# Altium schematic sheet Sensor_Box_Ethernet.SchDoc
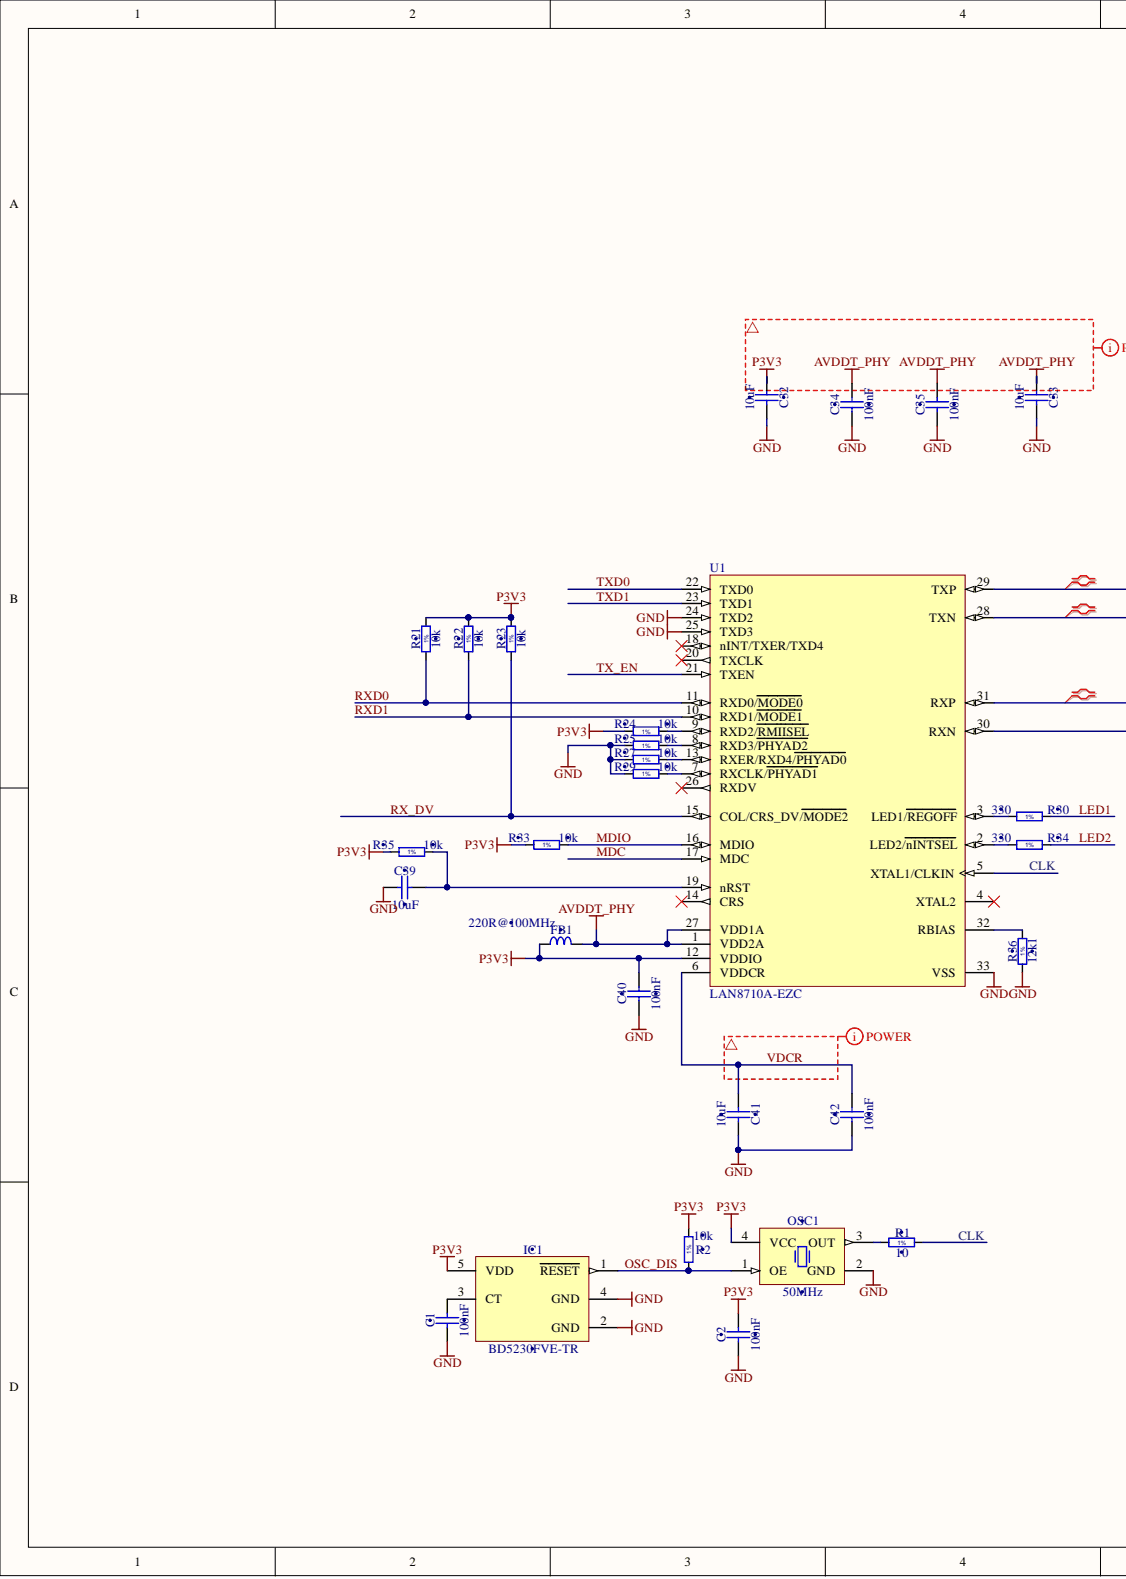
[diagram p0: Sensor_Box_Ethernet.SchDoc, left]
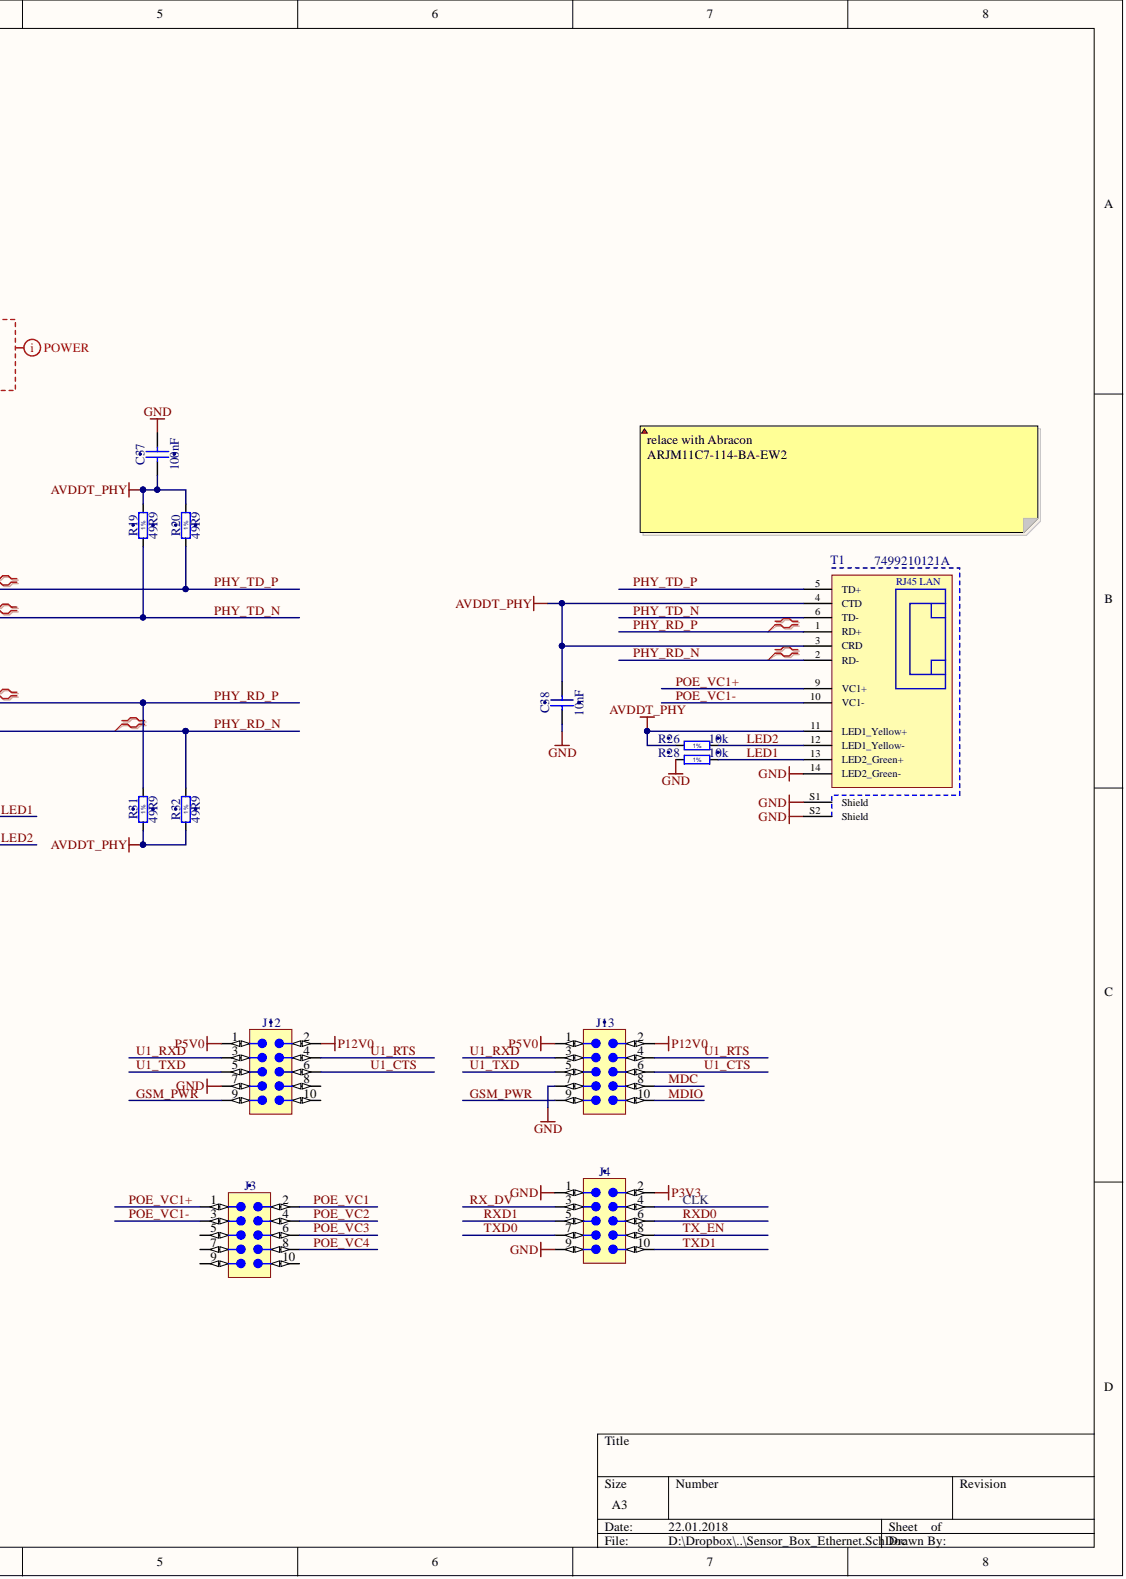
[diagram p1: Sensor_Box_Ethernet.SchDoc, right]

## Parts
C1  100nF  [CAPC1005X55N]
C2  100nF  [CAPC1005X55N]
C32  10uF  [CAPC2012X135N]
C33  10uF  [CAPC2012X135N]
C34  100nF  [CAPC1005X55N]
C35  100nF  [CAPC1005X55N]
C37  100nF  [CAPC1005X55N]
C38  10nF  [CAPC1608X87N]
C39  10uF  [CAPC2012X135N]
C40  100nF  [CAPC1005X55N]
C41  10uF  [CAPC2012X135N]
C42  100nF  [CAPC1005X55N]
FB1  220R@100MHz  [INDC2012X105N]
IC1  BD5230FVE-TR  [SOT95P280X145-5N]
J3  edge  [AVX_00-9159-010-061-916]  (value not drawn)
J4  edge  [AVX_00-9159-010-061-916]  (value not drawn)
J12  edge  [AVX_00-9159-010-061-916]  (value not drawn)
J13  edge  [AVX_00-9159-010-061-916]  (value not drawn)
OSC1  50MHz  [OSCSC254P320X500X130-4N]
R1  10  [RESC1005X40N]
R2  10k  [RESC1005X40N]
R19  49R9  [RESC1005X40N]
R20  49R9  [RESC1005X40N]
R21  10k  [RESC1005X40N]
R22  10k  [RESC1005X40N]
R23  10k  [RESC1005X40N]
R24  10k  [RESC1005X40N]
R25  10k  [RESC1005X40N]
R26  10k  [RESC1005X40N]
R27  10k  [RESC1005X40N]
R28  10k  [RESC1005X40N]
R29  10k  [RESC1005X40N]
R30  330  [RESC1005X40N]
R31  49R9  [RESC1005X40N]
R32  49R9  [RESC1005X40N]
R33  10k  [RESC1005X40N]
R34  330  [RESC1005X40N]
R35  10k  [RESC1005X40N]
R36  12k1  [RESC1005X40N]
T1  7499210121A  [7499210121A]
U1  LAN8710A-EZC  [MCHP-QFN-32-5x5_V1]

## Nets
AVDDT_PHY: C33.1 C34.2 C35.2 C37.1 C38.2 FB1.2 R19.2 R20.2 R26.2 R31.1 R32.1 T1.3(CRD) T1.4(CTD) T1.11(LED1_Yellow+) U1.1(VDD2A) U1.27(VDD1A)
GND: C1.1 C2.1 C32.2 C33.2 C34.1 C35.1 C37.2 C38.1 C39.1 C40.1 C41.2 C42.1 IC1.2(GND) IC1.4(GND) J4.1 J4.9 J12.7 J13.7 OSC1.2(GND) R25.2 R27.2 R28.2 R29.2 R36.1 T1.14(LED2_Green-) T1.S1(Shield) T1.S2(Shield) U1.24(TXD2) U1.25(TXD3) U1.33(VSS)
P3V3: C2.2 C32.1 C40.2 FB1.1 IC1.5(VDD) J4.2 OSC1.4(VCC) R2.1 R21.2 R22.2 R23.2 R24.2 R33.2 R35.2 U1.12(VDDIO)
P5V0: J12.1 J13.1
P12V0: J12.2 J13.2
CLK: J4.4 R1.2 U1.5(XTAL1/CLKIN)
GSM_PWR: J12.9 J13.9
LED1: R28.1 R30.2 T1.13(LED2_Green+)
LED2: R26.1 R34.2 T1.12(LED1_Yellow-)
MDC: J13.8 U1.17(MDC)
MDIO: J13.10 R33.1 U1.16(MDIO)
OSC_DIS: IC1.1(RESET) OSC1.1(OE) R2.2
PHY_RD_N: R32.2 T1.2(RD-) U1.30(RXN)
PHY_RD_P: R31.2 T1.1(RD+) U1.31(RXP)
PHY_TD_N: R19.1 T1.6(TD-) U1.28(TXN)
PHY_TD_P: R20.1 T1.5(TD+) U1.29(TXP)
POE_VC1: J3.2
POE_VC1+: J3.1 T1.9(VC1+)
POE_VC1-: J3.3 T1.10(VC1-)
POE_VC2: J3.4
POE_VC3: J3.6
POE_VC4: J3.8
RX_DV: J4.3 R23.1 U1.15(COL/CRS_DV/MODE2)
RXD0: J4.6 R21.1 U1.11(RXD0/MODE0)
RXD1: J4.5 R22.1 U1.10(RXD1/MODE1)
TX_EN: J4.8 U1.21(TXEN)
TXD0: J4.7 U1.22(TXD0)
TXD1: J4.10 U1.23(TXD1)
U1_CTS: J12.6 J13.6
U1_RTS: J12.4 J13.4
U1_RXD: J12.3 J13.3
U1_TXD: J12.5 J13.5
VDCR: C41.1 C42.2 U1.6(VDDCR)
NetC1_2: C1.2 IC1.3(CT)
NetC39_2: C39.2 R35.1 U1.19(nRST)
NetOSC1_3: OSC1.3(OUT) R1.1
NetR24_1: R24.1 U1.9(RXD2/RMIISEL)
NetR25_1: R25.1 U1.8(RXD3/PHYAD2)
NetR27_1: R27.1 U1.13(RXER/RXD4/PHYAD0)
NetR29_1: R29.1 U1.7(RXCLK/PHYAD1)
NetR30_1: R30.1 U1.3(LED1/REGOFF)
NetR34_1: R34.1 U1.2(LED2/nINTSEL)
NetR36_2: R36.2 U1.32(RBIAS)
N00009:
N00010:

Not connected: J3 5 7 9 10; J12 8 10; U1 4(XTAL2) 14(CRS) 18(nINT/TXER/TXD4) 20(TXCLK) 26(RXDV)

## Library data of the parts
C1 C2 C34 C35 C37 C40 C42 = CC0402_100NF_16V_10%_X5R: Non-polarised capacitor; Manufacturer: GENERIC; Manufacturer1 Part Number: GRM155R61C104KA88D; Part Description: SMD Multilayer Chip Ceramic Capacitor; Database Table Name: Capacitors SMD; TC: X5R; Tolerance: ±10%; Voltage: 16V; Case: 0402; Component Kind: Standard; Component Type: Standard; CreateDate: 10.12.2013; HelpURL: \\cern.ch\dfs\Applications\Altium\Datasheets\CC0402_X5R_MURATA_GRM.pdf; LatestRevisionDate: 10.12.2013; Library Name: Capacitors.DbLib; Manufacturer1 ComponentHeight: 0.55mm; Manufacturer1 Example: MURATA; Manufacturer Part Number: CC0402_100NF_16V_10%_X5R; Mounted: Yes; Part Number: CC0402_100NF_16V_10%_X5R; Pin Count: 2; Sense: No; SMD: Yes; Socket: No; Status: None; Value: 100nF; Author: CERN DEM JLC
C32 C33 C39 C41 = CC0805_10UF_16V_10%_X5R: Non-polarised capacitor; Manufacturer: GENERIC; Manufacturer1 Part Number: C0805C106K4PAC; Part Description: SMD Multilayer Chip Ceramic Capacitor; Database Table Name: Capacitors SMD; TC: X5R; Tolerance: ±10%; Voltage: 16V; Case: 0805; Component Kind: Standard; Component Type: Standard; CreateDate: 03.12.2007; HelpURL: \\cern.ch\dfs\Applications\Altium\Datasheets\CC1206_X5R_KEMET.pdf; LatestRevisionDate: 03.12.2007; Library Name: Capacitors.DbLib; Manufacturer1 ComponentHeight: 1.4mm; Manufacturer1 Example: KEMET; Manufacturer Part Number: CC0805_10UF_16V_10%_X5R; Mounted: Yes; Part Number: CC0805_10UF_16V_10%_X5R; Pin Count: 2; Sense: No; SMD: Yes; Socket: No; Status: Preferred; Value: 10uF; Author: CERN DEM JLC
C38 = CC0603_10NF_50V_5%_C0G: Non-polarised capacitor; Manufacturer: GENERIC; Manufacturer1 Part Number: C1608C0G1H103J080AA; Part Description: SMD Multilayer Chip Ceramic Capacitor; Database Table Name: Capacitors SMD; TC: C0G/NP0; Tolerance: ±5%; Voltage: 50V; Case: 0603; Component Kind: Standard; Component Type: Standard; CreateDate: 10.12.2014; HelpURL: \\cern.ch\dfs\Applications\Altium\Datasheets\CC0603_C0G_TDK_C.pdf; LatestRevisionDate: 10.12.2014; Library Name: Capacitors.DbLib; Manufacturer1 ComponentHeight: 0.9mm; Manufacturer1 Example: TDK; Manufacturer Part Number: CC0603_10NF_50V_5%_C0G; Mounted: Yes; Part Number: CC0603_10NF_50V_5%_C0G; Pin Count: 2; Sense: No; SMD: Yes; Socket: No; Status: Preferred; Value: 10nF; Author: CERN DEM JLC
FB1 = IND0805_MURATA_BLM21PG221SN1D: Inductor; Manufacturer: MURATA; Part Description: SMD EMIFIL Suppression Chip Ferrite Bead; Database Table Name: Inductors SMD; Power: 2A; Resistance: 0.05R; Tolerance: ±25%; Case: 0805; ComponentHeight: 1.05mm; Component Kind: Standard; Component Type: Standard; CreateDate: 2010-01-25; HelpURL: \\cern.ch\dfs\Applications\Altium\Datasheets\IND0402_MURATA_BLM21P.pdf; LatestRevisionDate: 2010-01-25; Library Name: Inductors & Transformers.DbLib; Manufacturer Part Number: BLM21PG221SN1D; Mounted: Yes; Part Number: IND0805_MURATA_BLM21PG221SN1D; Pin Count: 2; SMD: Yes; Socket: No; Status: None; Table: Inductors SMD; Value: 220R@100MHz; Author: CERN DEM JLC
IC1 = (no symbol name): Free Delay Time Setting  CMOS Voltage Detector; Manufacturer: Rohm; Part Description: 5V, Low Quiescent Current, Programmable-Delay Supervisory Circuit; Bonding: No; PressFit: No; Case: SOT23-5; ComponentHeight: 1.45mm; Component Kind: Standard; Component Type: Standard; CreateDate: 02.03.2015; Device: BD5230FVE-TR; HelpURL: \\cern.ch\dfs\Applications\Altium\Datasheets\TPS3808G50DBVT.pdf; LatestRevisionDate: 02.03.2015; Library Name: LIBRARY_SCH.SCHLIB; Manufacturer Part Number: BD5230FVE-TR; Mounted: Yes; PackageDescription: SOT23, 5-Leads, Body 1.6x3.0mm, Pitch 0.95mm, IPC Medium Density; Part Number: BD5230FVE-TR; Pin Count: 5; Sense: No; SMD: Yes; Socket: No; Author: GK
J3 J4 J12 J13 = (no symbol name): edge inline connector; Manufacturer: AVX; Part Description: 2.00mm (.079") Pitch Milli-Grid™ Header, Vertical, Shrouded, Center Polarization Slot, Locking Window, 14 Circuits (Customized PCB Edge assembly); PressFit: No; ComponentHeight: 3.15mm; Component Kind: Standard; Component Type: Standard; CreateDate: 13.04.2010; Family: edge; HelpURL: \\cern.ch\dfs\Applications\Altium\Datasheets\MOLEX_87831-1420.pdf; LatestRevisionDate: 13.04.2010; Library Name: LIBRARY_SCH.SCHLIB; Manufacturer Part Number: AVX_00-9159-010-061-916; Mounted: Yes; Part Number: AVX_00-9159-010-061-916; Pin Count: 14; Sense: No; SMD: No; Socket: No; Status: None; Author: GK
OSC1 = OSC_50MHZ_CTS_636L3I050M00000: Oscillator 4 pins 1=OE 2=GND 3=OUT 4=VCC; Manufacturer: CTS ELECTRONIC COMPONENTS; Part Description: 3.3V, ±50ppm, 30pF, -40..85°C, HCMOS/TTL Clock Oscillator, 636 Series; Bonding: No; Database Table Name: Crystals & Oscillators; PressFit: No; ComponentHeight: 1.3mm; Component Kind: Standard; Component Type: Standard; CreateDate: 22.09.2015; HelpURL: \\cern.ch\dfs\Applications\Altium\Datasheets\OSC_CTS_636.pdf; LatestRevisionDate: 22.09.2015; Library Name: ICs And Semiconductors.DbLib; Manufacturer Part Number: 636L3I050M00000; Mounted: Yes; PackageDescription: Oscillator, Side Concave; 2.54mm Pitch; 4 pin, 3.20mm L X 5.00mm W X 1.30mm H, IPC Medium Density; Part Number: OSC_50MHZ_CTS_636L3I050M00000; Pin Count: 4; Sense: No; SMD: Yes; Socket: No; Value: 50MHz; Author: CERN DEM JMW
R1 = R0402_10R_1%_0.0625W_200PPM: Resistor - 1%; Manufacturer: GENERIC; Manufacturer1 Part Number: 232270671009L; Part Description: General Purpose Thick Film Chip Resistor; Database Table Name: Resistors SMD; PressFit: No; TC: ±200ppm/°C; Case: 0402; Component Kind: Standard; Component Type: Standard; CreateDate: 24.06.2009; HelpURL: \\cern.ch\dfs\Applications\Altium\Datasheets\R0402_Phycomp_RC0402.pdf; LatestRevisionDate: 17.10.2012; Library Name: Resistors.DbLib; Manufacturer1 ComponentHeight: 0.4mm; Manufacturer1 Example: YAGEO PHYCOMP; Manufacturer Part Number: R0402_10R_1%_0.0625W_200PPM; Mounted: Yes; Part Number: R0402_10R_1%_0.0625W_200PPM; Pin Count: 2; Power: 0.0625W; Sense: No; SMD: Yes; Socket: No; Status: Not Recommended; Tolerance: ±1%; Value: 10; Author: CERN DEM JLC
R2 R21-R29 R33 R35 = R0402_10K_1%_0.0625W_100PPM: Resistor - 1%; Manufacturer: GENERIC; Manufacturer1 Part Number: 232270671003L; Part Description: General Purpose Thick Film Chip Resistor; Database Table Name: Resistors SMD; TC: ±100ppm/°C; Case: 0402; Component Kind: Standard; Component Type: Standard; CreateDate: 2007-12-03; HelpURL: \\cern.ch\dfs\Applications\Altium\Datasheets\R0402_Phycomp_RC0402.pdf; LatestRevisionDate: 2012-10-17; Library Name: Resistors.DbLib; Manufacturer1 ComponentHeight: 0.4mm; Manufacturer1 Example: YAGEO PHYCOMP; Manufacturer Part Number: R0402_10K_1%_0.0625W_100PPM; Mounted: Yes; Part Number: R0402_10K_1%_0.0625W_100PPM; Pin Count: 2; Power: 0.0625W; SMD: Yes; Socket: No; Status: Not recommended; Table: Resistors SMD; Tolerance: ±1%; Value: 10k; Author: CERN DEM JLC
R19 R20 R31 R32 = R0402_49R9_1%_0.0625W_100PPM: Resistor - 1%; Manufacturer: GENERIC; Manufacturer1 Part Number: 232270674999L or RC0402FR-0749R9L; Part Description: General Purpose Thick Film Chip Resistor; Database Table Name: Resistors SMD; TC: ±100ppm/°C; Case: 0402; Component Kind: Standard; Component Type: Standard; CreateDate: 2012-10-24; HelpURL: \\cern.ch\dfs\Applications\Altium\Datasheets\R0402_Phycomp_RC0402.pdf; LatestRevisionDate: 2012-10-24; Library Name: Resistors.DbLib; Manufacturer1 ComponentHeight: 0.4mm; Manufacturer1 Example: YAGEO PHYCOMP; Manufacturer Part Number: R0402_49R9_1%_0.0625W_100PPM; Mounted: Yes; Part Number: R0402_49R9_1%_0.0625W_100PPM; Pin Count: 2; Power: 0.0625W; SMD: Yes; Socket: No; Status: Not recommended; Table: Resistors SMD; Tolerance: ±1%; Value: 49R9; Author: CERN DEM JLC
R30 R34 = R0402_330R_1%_0.0625W_100PPM: Resistor - 1%; Manufacturer: GENERIC; Manufacturer1 Part Number: 232270673301L; Part Description: General Purpose Thick Film Chip Resistor; Database Table Name: Resistors SMD; PressFit: No; TC: ±100ppm/°C; Case: 0402; Component Kind: Standard; Component Type: Standard; CreateDate: 03.12.2007; HelpURL: \\cern.ch\dfs\Applications\Altium\Datasheets\R0402_Phycomp_RC0402.pdf; LatestRevisionDate: 17.10.2012; Library Name: Resistors.DbLib; Manufacturer1 ComponentHeight: 0.4mm; Manufacturer1 Example: YAGEO PHYCOMP; Manufacturer Part Number: R0402_330R_1%_0.0625W_100PPM; Mounted: Yes; Part Number: R0402_330R_1%_0.0625W_100PPM; Pin Count: 2; Power: 0.0625W; Sense: No; SMD: Yes; Socket: No; Status: Not Recommended; Tolerance: ±1%; Value: 330; Author: CERN DEM JLC
R36 = R0402_12K1_1%_0.0625W_100PPM: Resistor - 1%; Manufacturer: GENERIC; Manufacturer1 Part Number: 232270671213L or RC0402FR-0712K1L; Part Description: General Purpose Thick Film Chip Resistor; Database Table Name: Resistors SMD; TC: ±100ppm/°C; Case: 0402; Component Kind: Standard; Component Type: Standard; CreateDate: 2012-10-24; HelpURL: \\cern.ch\dfs\Applications\Altium\Datasheets\R0402_Phycomp_RC0402.pdf; LatestRevisionDate: 2012-10-24; Library Name: Resistors.DbLib; Manufacturer1 ComponentHeight: 0.4mm; Manufacturer1 Example: YAGEO PHYCOMP; Manufacturer Part Number: R0402_12K1_1%_0.0625W_100PPM; Mounted: Yes; Part Number: R0402_12K1_1%_0.0625W_100PPM; Pin Count: 2; Power: 0.0625W; SMD: Yes; Socket: No; Status: Not recommended; Table: Resistors SMD; Tolerance: ±1%; Value: 12k1; Author: CERN DEM JLC
T1 = 7499210121A: WE-RJ45 LAN Transformer, 10/100 Base-T, THT, Port 1x1, Tab Down; LED (Left-Right): yellow-green; PoE: PoE (up to 350 mA); USB: No; Component Kind: Standard; ComponentLink1Description: Manufacturer; ComponentLink1URL: http://www.we-online.de; ComponentLink2Description: Datasheet; ComponentLink2URL: http://katalog.we-online.de/pbs/datasheet/7499210121A.pdf; Data Rate: 10/100 Base-T; Library Name: ALTIUM-WE-LAN-RJ45.IntLib; Mounting Technology: THT; Operating Temperature: -40 to +85 degC; Part Number: 7499210121A; Port Number: 1x1; Published Date: Dec. 2017; Tab Position: Down; Publisher: Wurth Elektronik
U1 = CMP-0186-00333-1: Small Footprint, Low Power Consumption, Full-Featured MII/RMII 10/100 Ethernet Transceiver with HP, 1.6 to 3.6 V, 0 to 85 degC, 32-Pin QFN, RoHS, Tray; Manufacturer: Microchip; Downstream Ports: Ethernet x1; LEDs: 4; Op. Voltage (V): 3.3; Component Kind: Standard; ComponentLink1Description: Manufacturer URL; ComponentLink1URL: http://www.microchip.com/; ComponentLink2Description: Datasheet; ComponentLink2URL: http://ww1.microchip.com/downloads/en/DeviceDoc/8710a.pdf; ComponentLink3Description: Package Specification; ComponentLink3URL: http://ww1.microchip.com/downloads/en/DeviceDoc/8710a.pdf; DatasheetVersion: Rev. 1.4; Flexible I/O Voltage (V): 1.6 to 3.6; Interface (UpStream): MII/RMII; Library Name: Altium Content Vault; Mounting Technology: Surface Mount; PackageDescription: 32-Pin Quad Flat No-lead Package, Body 5 x 5 mm, Pitch 0.5 mm; PackageReference: QFN-32; PackageVersion: Rev. 1.4; Packing: Tray; PartNumber: LAN8710A-EZC; RoHS: TRUE; Temperature Range (degC): 0 to 85
